# Altium schematic sheet 03_Power_Switch.SchDoc(03_Power_Switch)
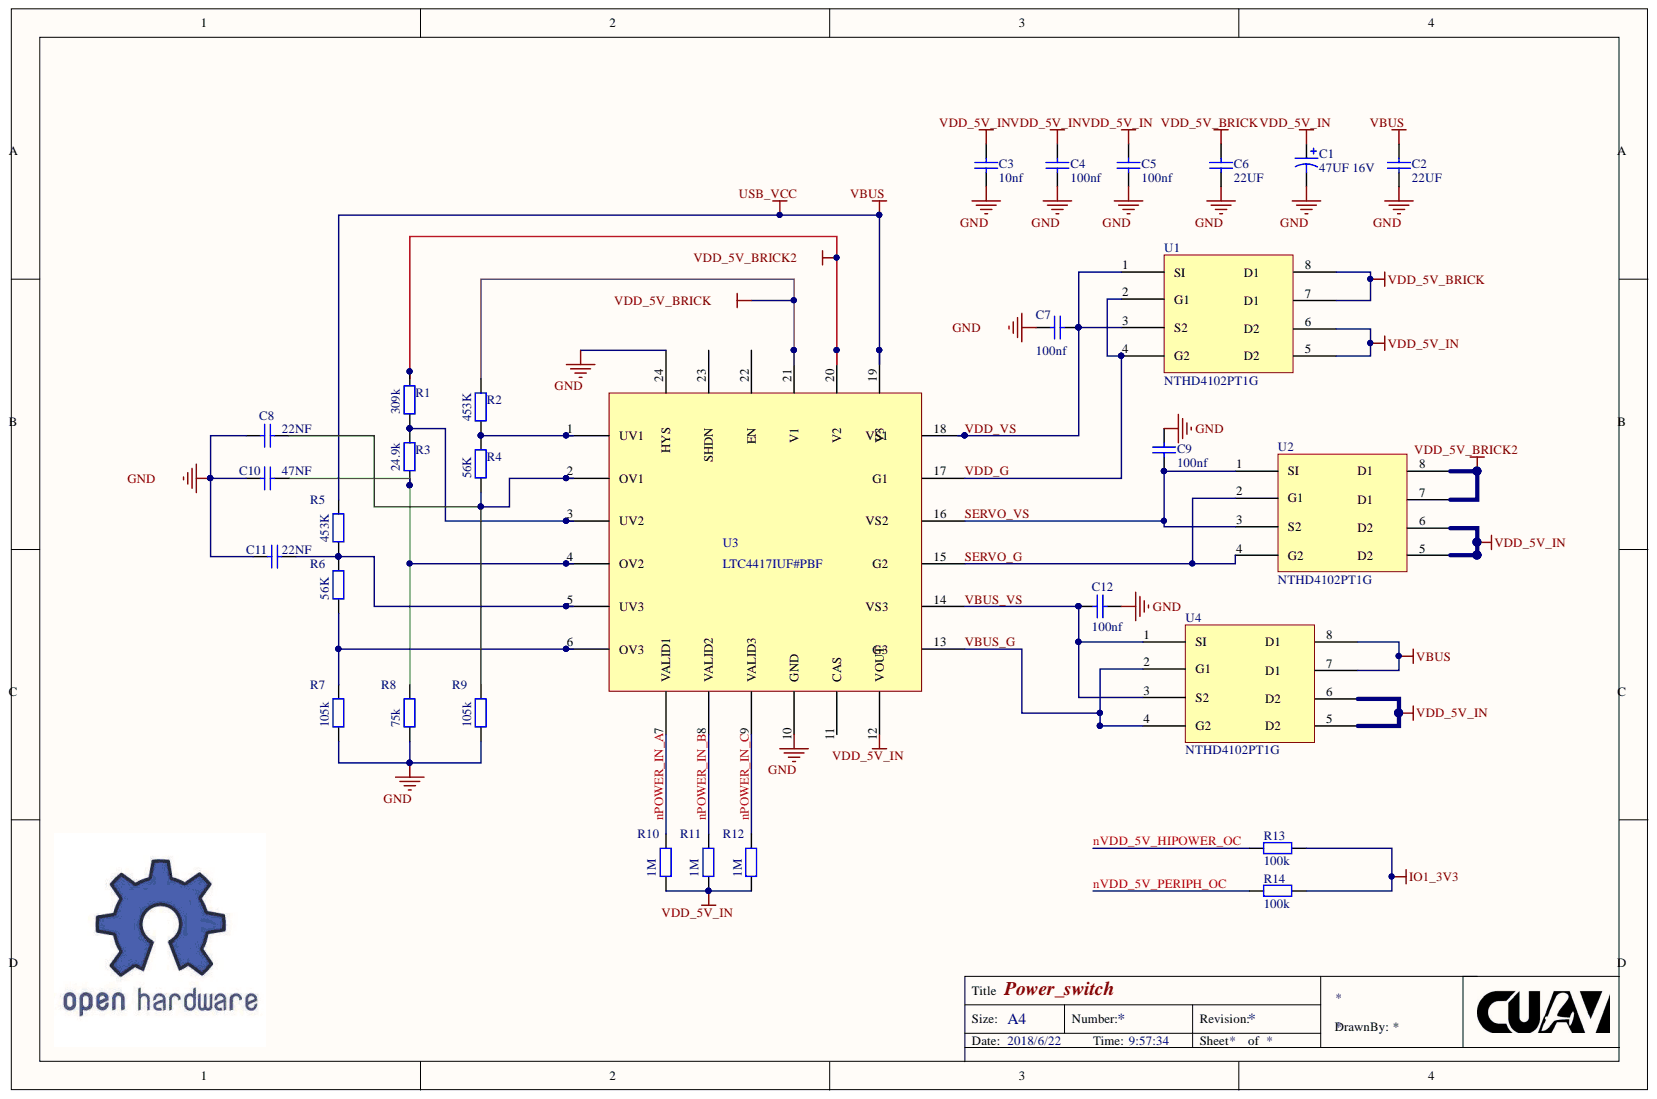
[diagram p0: 03_Power_Switch.SchDoc]

## Parts
C1  47UF 16V  [7343CAP]
C2  22UF  [0805CAP]
C3  10nf  [0402CAP]
C4  100nf  [0402CAP]
C5  100nf  [0402CAP]
C6  22UF  [0805CAP]
C7  100nf  [0402CAP]
C8  22NF  [0402CAP]
C9  100nf  [0402CAP]
C10  47NF  [0402CAP]
C11  22NF  [0402CAP]
C12  100nf  [0402CAP]
R1  309k  [R0402]
R2  453K  [R0402]
R3  24.9k  [R0402]
R4  56K  [R0402]
R5  453K  [R0402]
R6  56K  [R0402]
R7  105k  [R0402]
R8  75k  [R0402]
R9  105k  [R0402]
R10  1M  [R0402]
R11  1M  [R0402]
R12  1M  [R0402]
R13  100k  [R0402]
R14  100k  [R0402]
U1  NTHD4102PT1G  [1206-8]
U2  NTHD4102PT1G  [1206-8]
U3  LTC4417IUF#PBF  [QFN24]
U4  NTHD4102PT1G  [1206-8]

## Nets
GND: C1.C C2.1 C3.1 C4.1 C5.1 C6.1 C7.2 C8.2 C9.2 C10.2 C11.2 C12.2 R7.1 R8.1 R9.1 U3.10(GND) U3.24
IO1_3V3: R13.2 R14.2
USB_VCC: C2.2 R5.2 U3.19 U4.7 U4.8
VDD_5V_BRICK: C6.2 R2.2 U1.7 U1.8 U3.21
VDD_5V_BRICK2: R1.2 U2.7 U2.8 U3.20
VDD_5V_IN: C1.A C3.2 C4.2 C5.2 R10.1 R11.1 R12.1 U1.5 U1.6 U2.5 U2.6 U3.12(VOUT) U4.5 U4.6
NPOWER_IN_A: R10.2 U3.7(VALID1)
NPOWER_IN_B: R11.2 U3.8(VALID2)
NPOWER_IN_C: R12.2 U3.9(VALID3)
NVDD_5V_HIPOWER_OC: R13.1
NVDD_5V_PERIPH_OC: R14.1
SERVO_G: U2.2(G1) U2.4(G2) U3.15
SERVO_VS: C9.1 U2.1(SI) U2.3(S2) U3.16
VBUS_G: U3.13 U4.2(G1) U4.4(G2)
VBUS_VS: C12.1 U3.14 U4.1(SI) U4.3(S2)
VDD_G: U1.2(G1) U1.4(G2) U3.17
VDD_VS: C7.1 U1.1(SI) U1.3(S2) U3.18
NetC8_1: C8.1 R4.1 R9.2 U3.2(OV1)
NetC10_1: C10.1 R3.1 R8.2 U3.4(OV2)
NetC11_1: C11.1 R5.1 R6.2 U3.5(UV3)
NetR1_1: R1.1 R3.2 U3.3(UV2)
NetR2_1: R2.1 R4.2 U3.1(UV1)
NetR6_1: R6.1 R7.2 U3.6(OV3)

Not connected: U3 11(CAS) 22 23

## Library data of the parts
C1 = Cap Pol1: T520D476M016ATE070; Component Kind: Standard; Library Name: Miscellaneous Devices.IntLib; Signal Integrity: Cap Pol; Simulation: CAP
C2 C6 = Cap: 0805 22UF 25V; Component Kind: Standard; Library Name: Miscellaneous Devices.IntLib; Signal Integrity: Cap; Simulation: CAP
C3 = Cap: 0402 10NF 50V 10%; Component Kind: Standard; Library Name: Miscellaneous Devices.IntLib; Signal Integrity: Cap; Simulation: CAP
C4 C5 C7 C9 C12 = Cap: 0402 100NF 50V 10%; Component Kind: Standard; Library Name: Miscellaneous Devices.IntLib; Signal Integrity: Cap; Simulation: CAP
C8 C10 C11 = Cap: Component Kind: Standard; Library Name: Miscellaneous Devices.IntLib; Signal Integrity: Cap; Simulation: CAP
R1-R4 R6-R14 = Res2: Component Kind: Standard; Library Name: Miscellaneous Devices.IntLib; Signal Integrity: Res; Simulation: RESISTOR
R5 = Res2: Resistor; Component Kind: Standard; Library Name: Miscellaneous Devices.IntLib; Signal Integrity: Res; Simulation: RESISTOR
U1 U2 U4 = NTHD4102PT1G: Component Kind: Standard; Description: NTHD4102PT1G; Library Name: hack.SchLib
U3 = LTC4417IUF#PBF: Component Kind: Standard; Library Name: hack.SchLib
